# Altium schematic sheet I2S.SchDoc
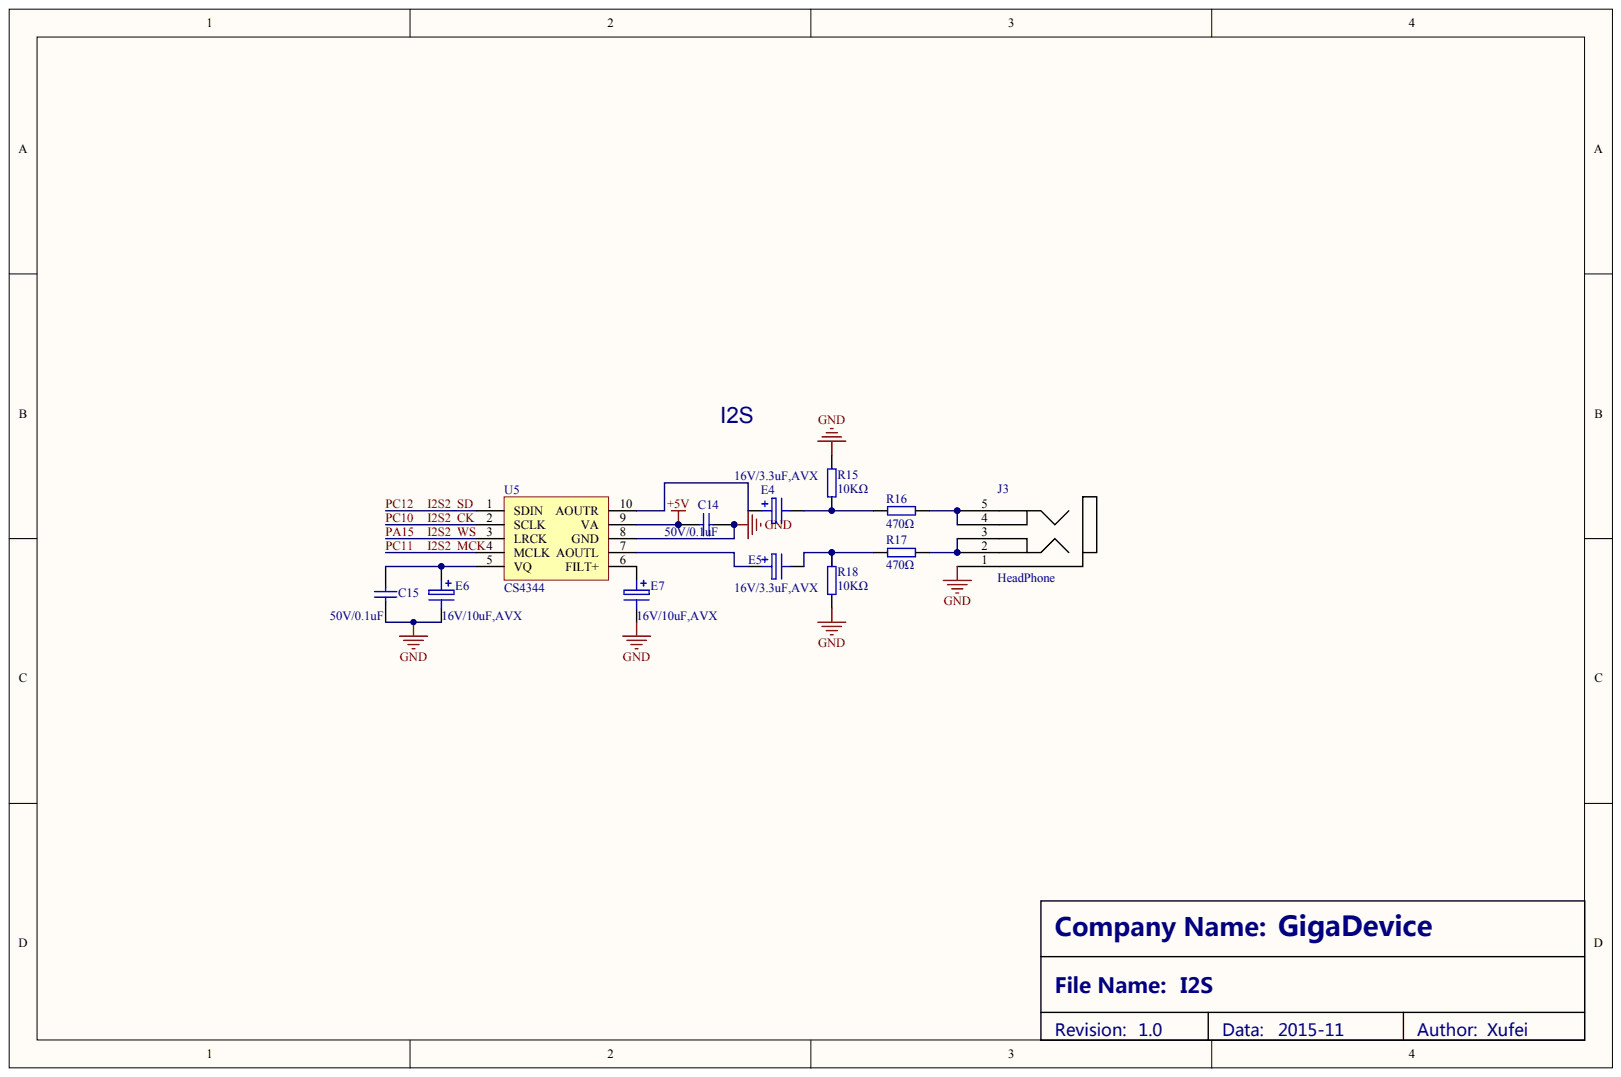
[diagram p0: I2S.SchDoc]

## Parts
C14  50V/0.1uF
C15  50V/0.1uF
E4  16V/3.3uF,AVX
E5  16V/3.3uF,AVX
E6  16V/10uF,AVX
E7  16V/10uF,AVX
J3  HeadPhone
R15  10KΩ
R16  470Ω
R17  470Ω
R18  10KΩ
U5  CS4344

## Nets
+5V: C14.1 U5.9(VA)
GND: C14.2 C15.2 E6.2 E7.2 J3.1 R15.2 R18.1 U5.8(GND)
I2S2_CK: U5.2(SCLK)
I2S2_MCK: U5.4(MCLK)
I2S2_SD: U5.1(SDIN)
I2S2_WS: U5.3(LRCK)
NetC15_1: C15.1 E6.1 U5.5(VQ)
NetE4_1: E4.1 U5.10(AOUTR)
NetE4_2: E4.2 R15.1 R16.1
NetE5_1: E5.1 U5.7(AOUTL)
NetE5_2: E5.2 R17.1 R18.2
NetE7_1: E7.1 U5.6(FILT+)
NetJ3_2: J3.2 J3.3 R17.2
NetJ3_4: J3.4 J3.5 R16.2
